# Altium schematic sheet Power.SchDot(Power)
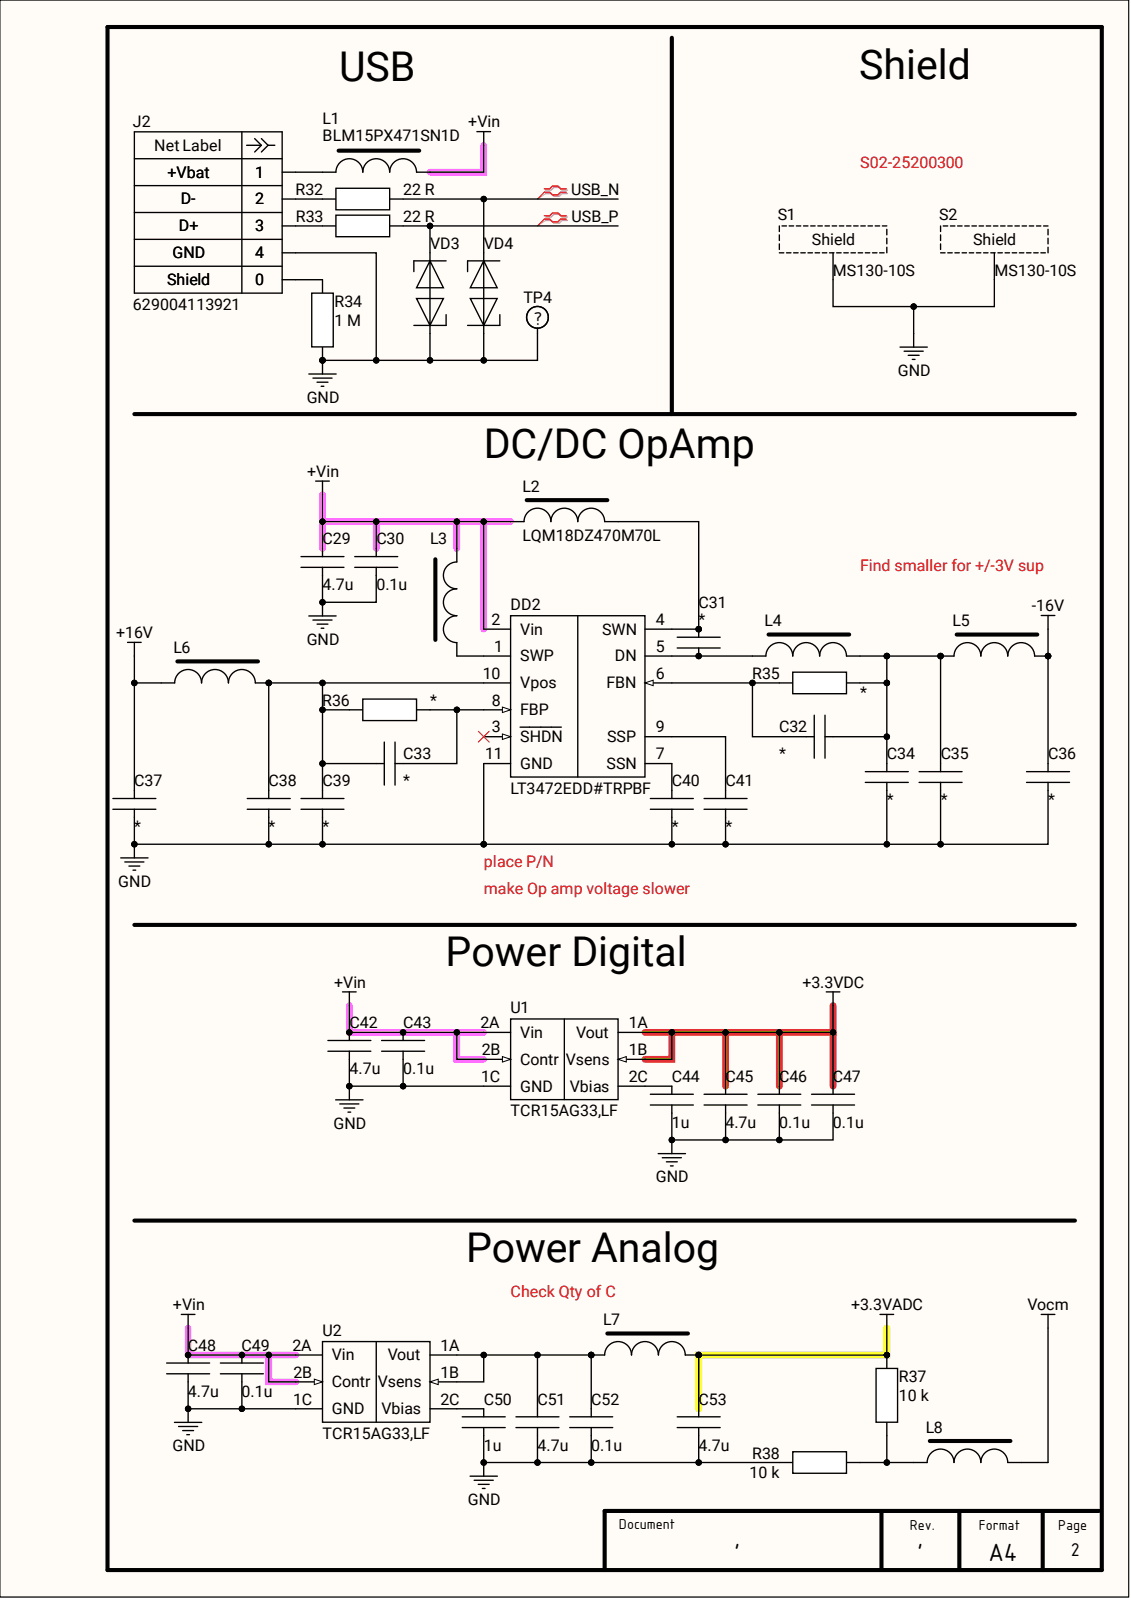
[diagram p0: Power.SchDot(Power)]

## Parts
C29  4.7u  [C0402]
C30  0.1u  [C0402]
C31  (no value)  [C0603]
C32  (no value)  [C0603]
C33  (no value)  [C0603]
C34  (no value)  [C0603]
C35  (no value)  [C0603]
C36  (no value)  [C0603]
C37  (no value)  [C0603]
C38  (no value)  [C0603]
C39  (no value)  [C0603]
C40  (no value)  [C0603]
C41  (no value)  [C0603]
C42  4.7u  [C0402]
C43  0.1u  [C0402]
C44  1u  [C0402]
C45  4.7u  [C0402]
C46  0.1u  [C0402]
C47  0.1u  [C0402]
C48  4.7u  [C0402]
C49  0.1u  [C0402]
C50  1u  [C0402]
C51  4.7u  [C0402]
C52  0.1u  [C0402]
C53  4.7u  [C0402]
DD2  LT3472EDD#TRPBF  [DFN-10]
J2  629004113921  [629004113921]
L1  BLM15PX471SN1D  [R0402]
L2  LQM18DZ470M70L  [L0603]
L3  LQM18DZ470M70L  [L0603]
L4  LQM18DZ470M70L  [L0603]
L5  BLM15PX471SN1D  [R0402]
L6  BLM15PX471SN1D  [R0402]
L7  BLM15PX471SN1D  [R0402]
L8  BLM15PX471SN1D  [R0402]
R32  22 R  [R0402]
R33  22 R  [R0402]
R34  1 M  [R0402]
R35  (no value)  [R0402]
R36  (no value)  [R0402]
R37  10 k  [R0402]
R38  10 k  [R0402]
S1  MS130-10S  [13_13_5mm]
S2  MS130-10S  [13_13_5mm]
TP4  5117  [Micro_test_pad]  (value not drawn)
U1  TCR15AG33,LF  [WCSP-6F-6]
U2  TCR15AG33,LF  [WCSP-6F-6]
VD3  8231706A  [R0402]  (value not drawn)
VD4  8231706A  [R0402]  (value not drawn)

## Nets
+3.3VADC: C53.1 L7.2 R37.2
+3.3VDC: C45.1 C46.1 C47.1 U1.1A(Vout) U1.1B(Vsens)
+16V: C37.2 L6.1
+Vin: C29.1 C30.1 C42.1 C43.1 C48.1 C49.1 DD2.2(Vin) L1.2 L2.1 L3.2 U1.2A(Vin) U1.2B(Contr) U2.2A(Vin) U2.2B(Contr)
-16V: C36.1 L5.2
GND: C29.2 C30.2 C34.2 C35.2 C36.2 C37.1 C38.1 C39.1 C40.2 C41.2 C42.2 C43.2 C44.1 C45.2 C46.2 C47.2 C48.2 C49.2 C50.1 C51.2 C52.2 C53.2 DD2.11(GND) J2.4 R34.1 R38.2 S1.1 S2.1 TP4.1 U1.1C(GND) U2.1C(GND) VD3.2 VD4.2
Vocm: L8.2
USB_N: R32.2 VD4.1
USB_P: R33.2 VD3.1
NetC31_1: C31.1 DD2.5(DN) L4.1
NetC31_2: C31.2 DD2.4(SWN) L2.2
NetC32_1: C32.1 DD2.6(FBN) R35.1
NetC32_2: C32.2 C34.1 C35.1 L4.2 L5.1 R35.2
NetC33_1: C33.1 C38.2 C39.2 DD2.10(Vpos) L6.2 R36.1
NetC33_2: C33.2 DD2.8(FBP) R36.2
NetC40_1: C40.1 DD2.7(SSN)
NetC41_1: C41.1 DD2.9(SSP)
NetC44_2: C44.2 U1.2C(Vbias)
NetC50_2: C50.2 U2.2C(Vbias)
NetC51_1: C51.1 C52.1 L7.1 U2.1A(Vout) U2.1B(Vsens)
NetDD2_1: DD2.1(SWP) L3.1
NetJ2_0: J2.0 R34.2
NetJ2_1: J2.1 L1.1
NetJ2_2: J2.2 R32.1
NetJ2_3: J2.3 R33.1
NetL8_1: L8.1 R37.1 R38.1

Not connected: DD2 3(SHDN)

## Library data of the parts
C29 C42 C45 C48 C51 C53 = MLCC: Multilayer Ceramic Capacitors MLCC - SMD/SMT 0402 X6S 4.7 F 20 10VDC AEC-Q200; Manufacturer: Murata; P/N: GRT155C81A475ME13D; Case: 0402; Component Kind: Standard; Component Type: MLCC; ComponentLink1Description: Link; ComponentLink2Description: Datasheet; Value: 4.7u; Library Name: Scheet.SchLib
  C29: ComponentLink1URL: https://eu.mouser.com/ProductDetail/Murata-Electronics/GRT155C81A475ME13D?qs=ufv2HEpFn%252BRTWI1%252Bv%2F3NwA%3D%3D; ComponentLink2URL: https://eu.mouser.com/datasheet/2/281/1/GRT155C81A475ME13_01A-3135426.pdf
C30 C43 C46 C47 C49 C52 = MLCC: Multilayer Ceramic Capacitors MLCC - SMD/SMT 0.1 uF 50 VDC 20% 0402 X6S; Manufacturer: Murate; P/N: GRM155C81H104ME14D; Case: 0402; Component Kind: Standard; Component Type: MLCC; ComponentLink1Description: Link; ComponentLink2Description: Datasheet; Value: 0.1u; Library Name: Scheet.SchLib
  C30: ComponentLink1URL: https://eu.mouser.com/ProductDetail/Murata-Electronics/GRM155C81H104ME14D?qs=IPQhFcwEUOignsDjW4gFkg%3D%3D; ComponentLink2URL: https://eu.mouser.com/datasheet/2/281/1/GRM155C81H104ME14_01A-1983691.pdf
C31-C41 = MLCC: Cercap smd; Component Kind: Standard; ComponentLink1Description: Link; ComponentLink2Description: Datasheet; Library Name: Scheet.SchLib
C44 C50 = MLCC: Multilayer Ceramic Capacitors MLCC - SMD/SMT 1 uF 10 VDC 20% 0402 X7S AEC-Q200; Manufacturer: Murata; P/N: GCM155C71A105ME38D; Case: 0402; Component Kind: Standard; Component Type: MLCC; ComponentLink1Description: Link; ComponentLink1URL: https://eu.mouser.com/ProductDetail/Murata-Electronics/GCM155C71A105ME38D?qs=QzBtWTOodeV5ddl%2FvWs3LA%3D%3D; ComponentLink2Description: Datasheet; ComponentLink2URL: https://eu.mouser.com/datasheet/2/281/1/GCM155C71A105ME38_01A-3142248.pdf; Value: 1u; Library Name: Scheet.SchLib
DD2 = LT3472EDD: Switching Voltage Regulators Boost & Inv DC/DC Conv for CCD Bias; Manufacturer: Analog Devices Inc.; Value: 34V, 0.2A; Case: DFN-10; Component Kind: Standard; Component Type: Switching Voltage Regulators; ComponentLink1Description: Datasheet; ComponentLink1URL: https://eu.mouser.com/datasheet/2/609/3472f-3123771.pdf; ComponentLink2Description: Link; ComponentLink2URL: https://eu.mouser.com/ProductDetail/Analog-Devices/LT3472EDDTRPBF?qs=hVkxg5c3xu%252ByMC99fvAIqQ%3D%3D; P/N: LT3472EDD#TRPBF; Library Name: Scheet.SchLib
J2 = 629004113921: USB Connectors WR-COM Type A SMT 4Pin W/Clip Male; Manufacturer: Wurth Elektronik; Value: USB A; Case: USB A; Component Kind: Standard; Component Type: USB Type A Connectors; ComponentLink1Description: Datasheet; ComponentLink1URL: https://www.we-online.com/components/products/datasheet/629004113921.pdf; ComponentLink2Description: Link; ComponentLink2URL: https://eu.mouser.com/ProductDetail/Wurth-Elektronik/629004113921?qs=35zkduByVmhHRkVkRjAlEQ%3D%3D; P/N: 629004113921; Library Name: Scheet.SchLib
L1 L5-L8 = BLM15PX471SN1D: Ferrite Beads 470 OHM 25% Alternate Sizing Guide Below; Manufacturer: Murata; Value: 470 Ohms, 1A; Case: 0402; Component Kind: Standard; Component Type: Ferrite Beads; ComponentLink1Description: Datasheet; ComponentLink1URL: https://eu.mouser.com/datasheet/2/281/ENFA0018-1915754.pdf; ComponentLink2Description: Link; ComponentLink2URL: https://eu.mouser.com/ProductDetail/Murata-Electronics/BLM15PX471SN1D?qs=eTNEoJdcnEJgxrnv%252BsVZMw%3D%3D; P/N: BLM15PX471SN1D; Library Name: Scheet.SchLib
L2-L4 = LQM18DZ470M70L: Power Inductors - SMD 0603 47uH 20% 180mA 3.32ohm AEC-Q200; Manufacturer: Murata; Case: 0603; Component Kind: Standard; Component Type: Power Inductors - SMD; ComponentLink1Description: Datasheet; ComponentLink1URL: https://eu.mouser.com/datasheet/2/281/1/JELF243B_9128-3158890.pdf; ComponentLink2Description: Link; ComponentLink2URL: https://eu.mouser.com/ProductDetail/Murata-Electronics/LQM18DZ470M70L?qs=aP1CjGhiNiGa4GC35xm0xg%3D%3D; P/N: LQM18DZ470M70L; Value: 180 mA; Library Name: Scheet.SchLib
R32 R33 R35 R36 = SMD Resistor: Res SMD; Manufacturer: Panasonic; P/N: ERJ-2GEJ220X; Case: 0402; Component Kind: Standard; ComponentLink1Description: Datasheet; ComponentLink2Description: Link; Library Name: Scheet.SchLib
  R32: ComponentLink1URL: https://eu.mouser.com/datasheet/2/315/AOA0000C301-1488782.pdf; ComponentLink2URL: https://eu.mouser.com/ProductDetail/Panasonic/ERJ-2GEJ220X?qs=sGAEpiMZZMvdGkrng054t8ugkoYZivkgE05%252BFXPHW90%3D; Value: 22 R
  R33: Value: 22 R
R34 = SMD Resistor: Thick Film Resistors 0402 5% 1.0Mohm Anti-Sulfur AEC-Q200; Manufacturer: Panasonic; P/N: ERJ-U02J105X; Case: 0402; Component Kind: Standard; ComponentLink1Description: Datasheet; ComponentLink1URL: https://eu.mouser.com/datasheet/2/315/AOA0000C334-1314047.pdf; ComponentLink2Description: Link; ComponentLink2URL: https://eu.mouser.com/ProductDetail/Panasonic/ERJ-U02J105X?qs=sGAEpiMZZMvdGkrng054txgTq5MjvbWt4CoE%2F2ATr1A%3D; Value: 1 M; Library Name: Scheet.SchLib
R37 R38 = SMD Resistor: Thick Film Resistors - SMD 0402 10Kohms 0.5%AEC-Q200; Manufacturer: Panasonic; P/N: ERJ-2RHD103X; Case: 0402; Component Kind: Standard; ComponentLink1Description: Datasheet; ComponentLink1URL: https://eu.mouser.com/datasheet/2/315/AOA0000C304-1149620.pdf; ComponentLink2Description: Link; ComponentLink2URL: https://eu.mouser.com/ProductDetail/Panasonic/ERJ-2RKF2202X?qs=sGAEpiMZZMvdGkrng054t8AJgcdMkx7xGCv6QlnvaAM%3D; Value: 10 k; Library Name: Scheet.SchLib
S1 S2 = MS130-10S: EMI Gaskets, Sheets, Absorbers & Shielding 13 x 13 x 5mm One-piece Drawn-Seamless RF Shield/EMI Shield (CRS); Manufacturer: Masach Tech; Case: 13 x 13 x 5mm; Component Kind: Standard; Component Type: Shields; ComponentLink1Description: Datasheet; ComponentLink1URL: https://api.masach.com/media/catalog/product/file/MS128-10.pdf; ComponentLink2Description: Link; ComponentLink2URL: https://eu.mouser.com/ProductDetail/Masach/MS130-10S?qs=pUKx8fyJudDNyG0ZPUxt%2FA%3D%3D; P/N: MS130-10S; Value: 13 x 13 x 5mm; Library Name: Scheet.SchLib
TP4 = (no symbol name): PCB TEST POINT BLUE; Manufacturer: Keystone Electronics; Value: 36-5117; Case: THT; Component Kind: Standard; Component Type: Test point; ComponentLink1Description: Datasheet; ComponentLink1URL: https://ru.mouser.com/datasheet/2/215/5115_5119-744073.pdf; ComponentLink2Description: Link; ComponentLink2URL: https://ru.mouser.com/ProductDetail/Keystone-Electronics/5117?qs=%2Fha2pyFadujSuVybhyFc6oqj%252BXCGMBBx2uPmIz4OBqU%3D; Library Name: Others.SchLib; P/N: 5117
U1 U2 = TCR15AG33,LF: LDO Voltage Regulators LDO Linear Voltage Regulator 1.5A 3.3V; Manufacturer: Toshiba; Value: 3V3, 1.5A; Case: WCSP-6F-6; Component Kind: Standard; Component Type: LDO Voltage Regulators; ComponentLink1Description: Datasheet; ComponentLink1URL: https://eu.mouser.com/datasheet/2/408/TCR15AG33_datasheet_en_20230824-1916466.pdf; ComponentLink2Description: Link; ComponentLink2URL: https://eu.mouser.com/ProductDetail/Toshiba/TCR15AG33LF?qs=W0yvOO0ixfEdwQi51FhISQ%3D%3D; P/N: TCR15AG33,LF; Library Name: Scheet.SchLib
VD3 VD4 = 8231706A: ESD Suppressors / TVS Diodes WE-VE femtoF 0402 6V 0.05pF 0.05uA; Manufacturer: Wurth Elektronik; Value: 6 VDC; Case: 0402; Component Kind: Standard; Component Type: ESD Suppressors / TVS Diodes; ComponentLink1Description: Datasheet; ComponentLink1URL: https://www.we-online.com/components/products/datasheet/8231706A.pdf; ComponentLink2Description: Link; ComponentLink2URL: https://eu.mouser.com/ProductDetail/Wurth-Elektronik/8231706A?qs=wnTfsH77Xs6uU3vjj%252BDu6g%3D%3D; P/N: 8231706A; Library Name: Scheet.SchLib
